# Altium schematic sheet 8051_RAM.SchDoc(8051_RAM)
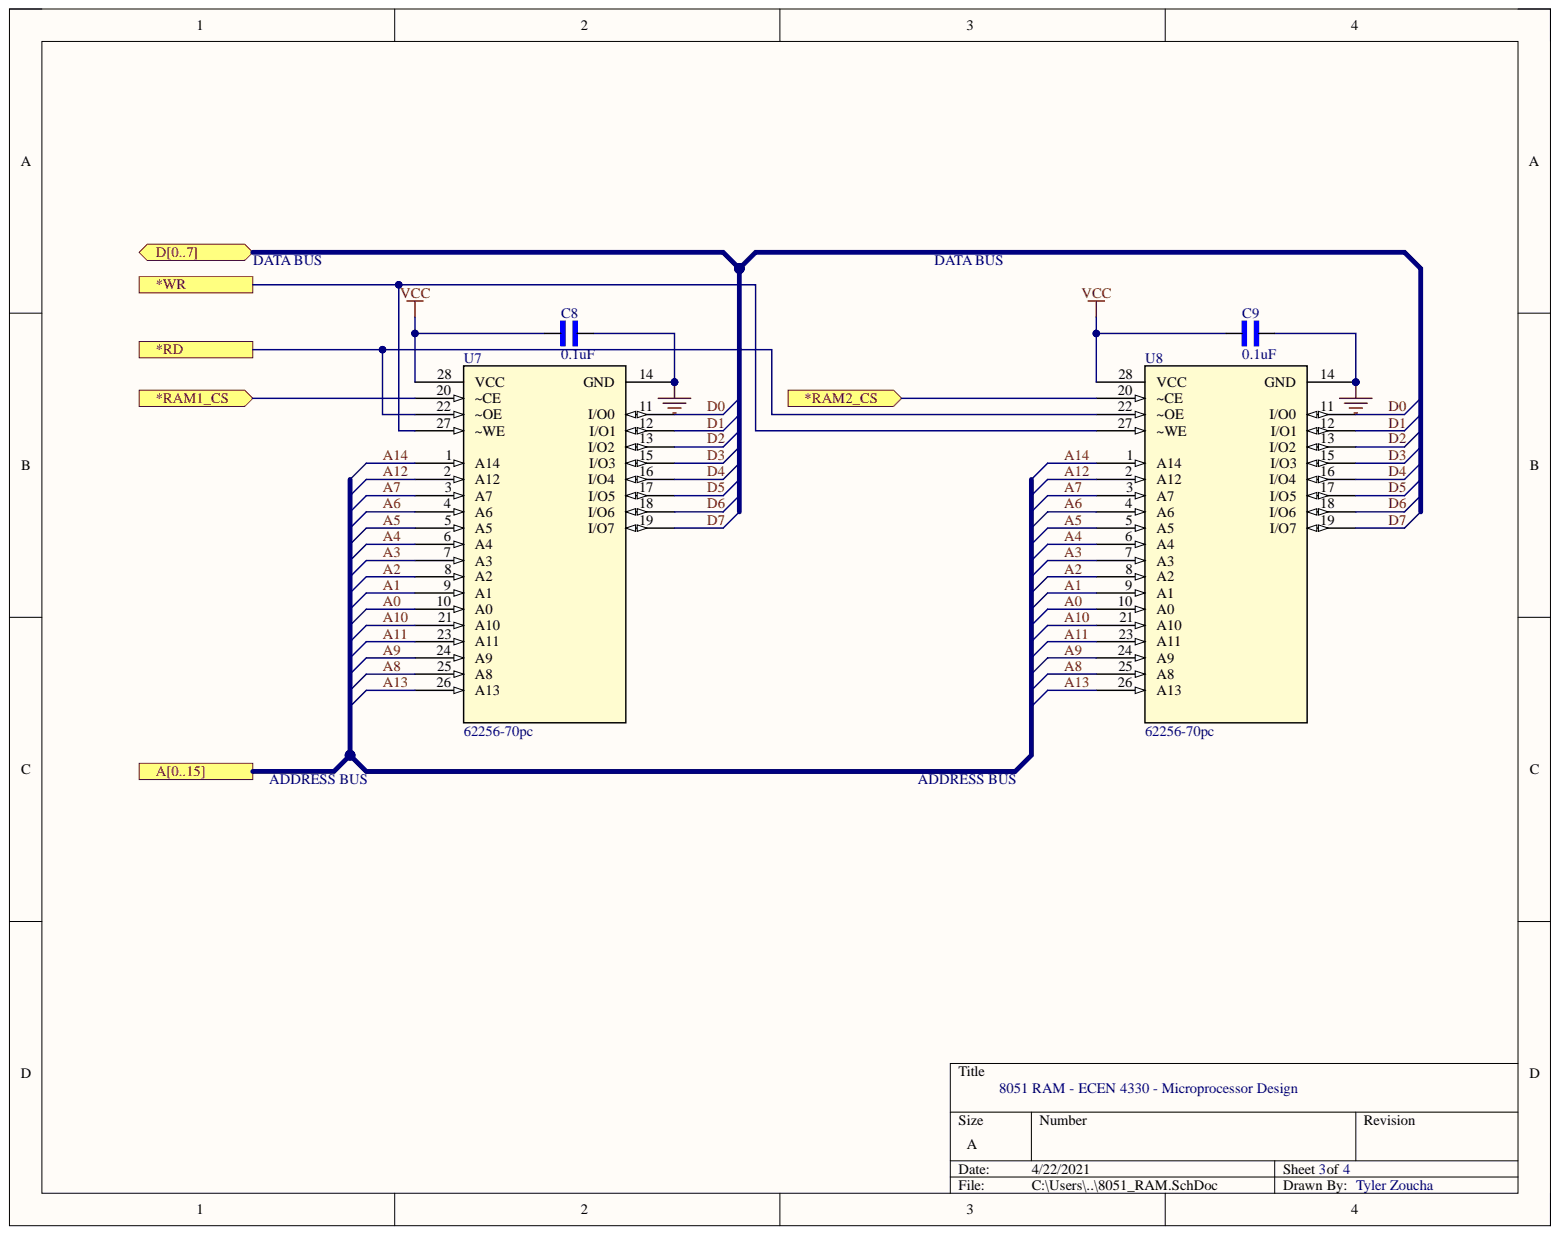
[diagram p0: 8051_RAM.SchDoc]

## Parts
C8  0.1uF  [CAP_RDER73A681K2M1H03A]
C9  0.1uF  [CAP_RDER73A681K2M1H03A]
U7  62256-70pc  [P15]
U8  62256-70pc  [P15]

## Ports
*RAM1_CS, *RAM2_CS, *RD, *WR, A0, A1, A2, A3, A4, A5, A6, A7, A8, A9, A10, A11, A12, A13, A14, A15, A[0..15], D0, D1, D2, D3, D4, D5, D6, D7, D[0..7]

## Nets
VCC: C8.1 C9.1 U7.28(VCC) U8.28(VCC)
*RAM1_CS: U7.20(~CE) ; port *RAM1_CS
*RAM2_CS: U8.20(~CE) ; port *RAM2_CS
*RD: U7.22(~OE) U8.22(~OE) ; port *RD
*WR: U7.27(~WE) U8.27(~WE) ; port *WR
A0: U7.10(A0) U8.10(A0) ; port A[0..15]  [also labelled ]
A1: U7.9(A1) U8.9(A1) ; port A[0..15]  [also labelled ]
A2: U7.8(A2) U8.8(A2) ; port A[0..15]  [also labelled ]
A3: U7.7(A3) U8.7(A3) ; port A[0..15]  [also labelled ]
A4: U7.6(A4) U8.6(A4) ; port A[0..15]  [also labelled ]
A5: U7.5(A5) U8.5(A5) ; port A[0..15]  [also labelled ]
A6: U7.4(A6) U8.4(A6) ; port A[0..15]  [also labelled ]
A7: U7.3(A7) U8.3(A7) ; port A[0..15]  [also labelled ]
A8: U7.25(A8) U8.25(A8) ; port A[0..15]  [also labelled ]
A9: U7.24(A9) U8.24(A9) ; port A[0..15]  [also labelled ]
A10: U7.21(A10) U8.21(A10) ; port A[0..15]  [also labelled ]
A11: U7.23(A11) U8.23(A11) ; port A[0..15]  [also labelled ]
A12: U7.2(A12) U8.2(A12) ; port A[0..15]  [also labelled ]
A13: U7.26(A13) U8.26(A13) ; port A[0..15]  [also labelled ]
A14: U7.1(A14) U8.1(A14) ; port A[0..15]  [also labelled ]
D0: U7.11(I/O0) U8.11(I/O0) ; port D[0..7]  [also labelled ]
D1: U7.12(I/O1) U8.12(I/O1) ; port D[0..7]  [also labelled ]
D2: U7.13(I/O2) U8.13(I/O2) ; port D[0..7]  [also labelled ]
D3: U7.15(I/O3) U8.15(I/O3) ; port D[0..7]  [also labelled ]
D4: U7.16(I/O4) U8.16(I/O4) ; port D[0..7]  [also labelled ]
D5: U7.17(I/O5) U8.17(I/O5) ; port D[0..7]  [also labelled ]
D6: U7.18(I/O6) U8.18(I/O6) ; port D[0..7]  [also labelled ]
D7: U7.19(I/O7) U8.19(I/O7) ; port D[0..7]  [also labelled ]
NetC8_2: C8.2 C9.2 U7.14(GND) U8.14(GND)
N00002_15: ; port A[0..15]

## Library data of the parts
C8 C9 = RDER73A681K2M1H03A: Lead capacitor X7R(EIA) with Capacitance 680pF, Rated Voltage 1000Vdc; MF: Murata; Arrow_Electronics-Purchase-URL: https://snapeda.com/shop?store=Arrow+Electronics&id=4546400; Availability: Good; Check_prices: https://www.snapeda.com/parts/RDER73A681K2M1H03A/Murata/view-part/4546400/?ref=eda; Component Kind: Standard; Library Name: leadCapacitor.SchLib; Mouser-Purchase-URL: https://snapeda.com/shop?store=Mouser&id=4546400; MP: RDER73A681K2M1H03A; Package: Radial Murata; Price: None; SnapEDA_Link: https://www.snapeda.com/parts/RDER73A681K2M1H03A/Murata/view-part/4546400/?ref=snap
U7 U8 = cy62256-70pc: No Description Available; Built_By: EMA_UL_Team; SourceLibrary: Cypress_2011-4-20; Vendor: Cypress Semiconductor; Component Kind: Standard; Library Name: 62256.SchLib; Manufacturer_Part_Number: cy62256-70pc; RefDes: RefDes; Type: DEV; Copyright: Copyright (C) 2018 Accelerated Designs. All rights reserved
